# Altium schematic sheet Measurements.SchDoc
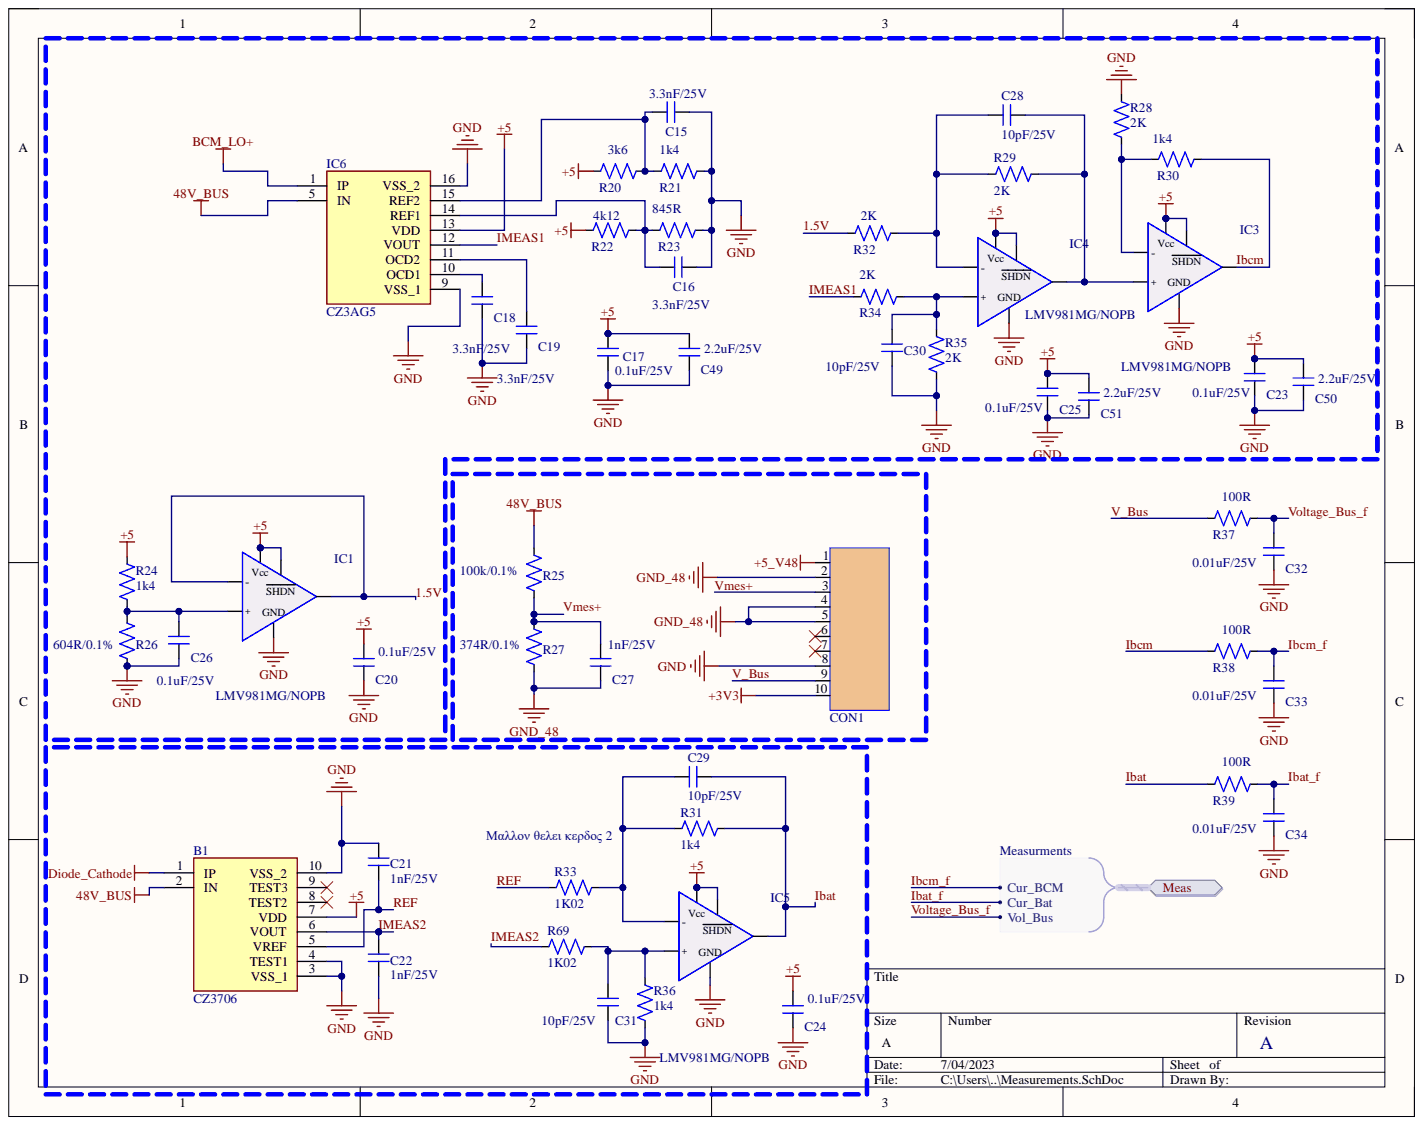
[diagram p0: Measurements.SchDoc]

## Parts
B1  CZ3706  [CZ3706]
C15  3.3nF/25V  [C0402]
C16  3.3nF/25V  [C0402]
C17  0.1uF/25V  [C0402]
C18  3.3nF/25V  [C0402]
C19  3.3nF/25V  [C0402]
C20  0.1uF/25V  [C0402]
C21  1nF/25V  [C0402]
C22  1nF/25V  [C0402]
C23  0.1uF/25V  [C0402]
C24  0.1uF/25V  [C0402]
C25  0.1uF/25V  [C0402]
C26  0.1uF/25V  [C0402]
C27  1nF/25V  [C0402]
C28  10pF/25V  [C0402]
C29  10pF/25V  [C0402]
C30  10pF/25V  [C0402]
C31  10pF/25V  [C0402]
C32  0.01uF/25V  [C0402]
C33  0.01uF/25V  [C0402]
C34  0.01uF/25V  [C0402]
C49  2.2uF/25V  [C0402]
C50  2.2uF/25V  [C0402]
C51  2.2uF/25V  [C0402]
CON1  SSQ-110-01-T-S  [Con1x10_2.54]  (value not drawn)
IC1  LMV981MG/NOPB  [SC-70-6]
IC3  LMV981MG/NOPB  [SC-70-6]
IC4  LMV981MG/NOPB  [SC-70-6]
IC5  LMV981MG/NOPB  [SC-70-6]
IC6  CZ3AG5  [CZ3AG5]
R20  3k6  [R0402]
R21  1k4  [R0402]
R22  4k12  [R0402]
R23  845R  [R0402]
R24  1k4  [R0402]
R25  100k/0.1%  [R0402]
R26  604R/0.1%  [R0402]
R27  374R/0.1%  [R0402]
R28  2K  [R0402]
R29  2K  [R0402]
R30  1k4  [R0402]
R31  1k4  [R0402]
R32  2K  [R0402]
R33  1K02  [R0402]
R34  2K  [R0402]
R35  2K  [R0402]
R36  1k4  [R0402]
R37  100R  [R0402]
R38  100R  [R0402]
R39  100R  [R0402]
R69  1K02  [R0402]

## Ports
Meas, Meas.Cur_Bat, Meas.Cur_BCM, Meas.Vol_Bus

## Nets
48V_BUS: B1.2(IN) IC6.5(IN) R25.2
+3V3: CON1.10
+5: B1.7(VDD) C17.1 C20.1 C23.1 C24.1 C25.1 C49.1 C50.1 C51.1 IC1.5 IC1.6 IC3.5 IC3.6 IC4.5 IC4.6 IC5.5 IC5.6 IC6.13(VDD) R20.1 R22.1 R24.2
+5_V48: CON1.1
BCM_LO+: IC6.1(IP)
Diode_Cathode: B1.1(IP)
GND: B1.3(VSS_1) B1.4(TEST1) B1.10(VSS_2) C15.2 C16.2 C17.2 C18.2 C19.2 C20.2 C21.2 C22.1 C23.2 C24.2 C25.2 C26.2 C30.2 C31.2 C32.2 C33.2 C34.2 C49.2 C50.2 C51.2 CON1.8 IC1.2 IC3.2 IC4.2 IC5.2 IC6.9(VSS_1) IC6.16(VSS_2) R21.2 R23.2 R26.1 R28.2 R35.1 R36.1
GND_48: C27.2 CON1.2 CON1.4 CON1.5 R27.1
1.5V: IC1.3(-) IC1.4 R32.1
Ibat: C29.2 IC5.4 R31.2 R39.1
Ibat_f: C34.1 R39.2 ; port Meas
Ibcm: IC3.4 R30.2 R38.1
Ibcm_f: C33.1 R38.2 ; port Meas
IMEAS1: IC6.12(VOUT) R34.1
IMEAS2: B1.6(VOUT) C22.2 R69.2
REF: B1.5(VREF) C21.1 R33.2
V_Bus: CON1.9 R37.1
Vmes+: C27.1 CON1.3 R25.1 R27.2
Voltage_Bus_f: C32.1 R37.2 ; port Meas
NetC15_1: C15.1 IC6.15(REF2) R20.2 R21.1
NetC16_1: C16.1 IC6.14(REF1) R22.2 R23.1
NetC18_1: C18.1 IC6.10(OCD1)
NetC19_1: C19.1 IC6.11(OCD2)
NetC26_1: C26.1 IC1.1(+) R24.1 R26.2
NetC28_1: C28.1 IC4.3(-) R29.1 R32.2
NetC28_2: C28.2 IC3.1(+) IC4.4 R29.2
NetC29_1: C29.1 IC5.3(-) R31.1 R33.1
NetC30_1: C30.1 IC4.1(+) R34.2 R35.2
NetC31_1: C31.1 IC5.1(+) R36.2 R69.1
NetIC3_3: IC3.3(-) R28.1 R30.1

Not connected: B1 8(TEST2) 9(TEST3); CON1 6 7

## Library data of the parts
B1 = CZ3706: Current Sensor 58.3A 1 Channel Hall Effect, Open Loop Bidirectional 16-PowerSOIC (0.303", 7.70mm Width), 10 Leads; Manufacturer_Name: AKM; Manufacturer_Part_Number: CZ3AG5; Geometry.Height: 2.45mm; Component Kind: Standard; Datasheet Link: https://www.akm.com/content/dam/documents/products/current-sensor/cz3ag5/cz3ag5-en-datasheet.pdf; Library Name: CZ3706.SchLib
C15 C16 C18 C19 = CC0402KRX7R8BB332: 0402 3.3 nF 25 V ±10 % Tolerance X7R SMT Mult; Manufacturer: Yageo; Capacitance: 3.3nF; Database Table Name: components; Dielectric Material: Ceramic; Tolerance: 10%; Voltage Rating: 25V; Case Code (Imperial): 0402; Case Code (Metric): 1005; Case/Package: 0402; Category: Ceramic Capacitors; Component Kind: Standard; Dielectric: X7R; Library Name: Resistors-Capacitors.DbLib; Manufacturer Part Number: CC0402KRX7R8BB332; Max Operating Temperature: 125°C; Min Operating Temperature: -55°C; Mount: Surface Mount; Packaging: Cut Tape; Part Number: CC0402KRX7R8BB332; Pricing 1: 1=0,10000, 10=0,01300, 50=0,01300, 100=0,0110; Resistor Type: Ceramic; RoHS: Yes; Stock 1: 41479; Supplier 1: Mouser; Supplier Part Number 1: 603-CC402KRX7R8BB332; Termination: SMD/SMT; Voltage Rating (DC): 25V; Weight: 2.3E-05oz
C17 C20 C23-C26 = C0402C104K3RACTU: Multilayer Ceramic 25V 0.1uF X7R 0402 10%; Manufacturer: Yageo; Database Table Name: components; Case/Package: 0402; Component Kind: Standard; Library Name: Resistors-Capacitors.DbLib; Part Number: C0402C104K3RACTU; Supplier 1: Mouser; Supplier Part Number 1: 80-C0402C104K3R
C21 C22 C27 = AC0402KRX7R8BB102: Cap Ceramic 0.001uF 25V X7R 10% SMD 0402 125°; Manufacturer: Yageo; Capacitance: 1nF; Database Table Name: components; Case Code (Imperial): 0402; Case Code (Metric): 1005; Case/Package: 0402; Category: Ceramic Capacitors; Component Kind: Standard; ComponentLink1Description: http://www.yageo.com/documents/recent/UPY-AC_; ComponentLink1URL: http://www.yageo.com/documents/recent/UPY-AC_; ComponentLink2Description: https://www.yageo.com/upload/media/product/pr; ComponentLink2URL: https://www.yageo.com/upload/media/product/pr; Library Name: Resistors-Capacitors.DbLib; Manufacturer Part Number: AC0402KRX7R8BB102; Max Operating Temperature: 125°C; Min Operating Temperature: -55°C; Mount: Surface Mount; Packaging: Cut Tape; Part Number: AC0402KRX7R8BB102; Pricing 1: 1=0,10000, 10=0,02700, 50=0,02700, 100=0,0220; Ratings: AEC-Q200; RoHS: Yes; RoHS Compliant: Yes; Stock 1: 53012; Supplier 1: Mouser; Supplier Part Number 1: 603-AC402KRX7R8BB102; Tolerance: 10%; Voltage Rating: 25V
C28-C31 = GRM1555C1E100GA01D: Cap Ceramic 10pF 25V C0G 2% Pad SMD 0402 125C; Manufacturer: Murata; Database Table Name: components; Capacitance: 10pF; Category: Ceramic Capacitors; Component Kind: Standard; Dielectric: C0G; Length: 1mm; Library Name: Resistors-Capacitors.DbLib; Manufacturer Part Number: GRM1555C1E100GA01D; Part Number: GRM1555C1E100GA01D; Pricing 1: 1=0,10000, 10=0,02600, 50=0,02600, 100=0,0120; RoHS: Yes; RoHS Compliant: Yes; Stock 1: 74874; Supplier 1: Mouser; Supplier Part Number 1: 81-GRM1555C1E100GA1D; Thickness: 500um; Tolerance: 2%; Voltage Rating (DC): 25V; Width: 500um
C32-C34 = C0402C103K3RAC7411: Multilayer Ceramic 25V 0.01uF X7R 0402 10%; Manufacturer: Yageo; Database Table Name: components; Case/Package: 0402; Component Kind: Standard; Library Name: Resistors-Capacitors.DbLib; Part Number: C0402C103K3RAC7411; Supplier 1: Mouser; Supplier Part Number 1: 80-C0402C103K3R7411
C49-C51 = GRM155C81E225ME11D: Ceramic 2.2UF 25V 20% 0402; Database Table Name: components; Case/Package: 0402; Component Kind: Standard; Library Name: Resistors-Capacitors.DbLib; Part Number: GRM155C81E225ME11D
CON1 = SSQ-110-01-T-S: Headers & Wire Housings Tiger Buy Socket Stri; Manufacturer: Samtec; Approvals: UR; Connector Type: Receptacle; Contact Current Rating: 3A; Contact Resistance: 10mR; Database Table Name: connectors; Gender: Female; Max Current Rating: 7.6A; Max Voltage Rating (AC): 550V; Number of Contacts: 10; Pin Length: 12mm; Pitch: 2.54mm; Plating Thickness: 0.381um; Row Spacing: 0.05inch; Case/Package: Module; Category: Headers and Wire Housings; Color: Black; Component Kind: Standard; ComponentLink1Description: https://datasheet.ciiva.com/748/ssq-1xx-xx-xx; ComponentLink1URL: https://datasheet.ciiva.com/748/ssq-1xx-xx-xx; ComponentLink2Description: https://datasheet.ciiva.com/409/m50-390-40926; ComponentLink2URL: https://datasheet.ciiva.com/409/m50-390-40926; ComponentLink3Description: https://datasheet.ciiva.com/8047/1693795-8047; ComponentLink3URL: https://datasheet.ciiva.com/8047/1693795-8047; ComponentLink4Description: https://datasheet.ciiva.com/8047/m50-390-8047; ComponentLink4URL: https://datasheet.ciiva.com/8047/m50-390-8047; ComponentLink5Description: https://datasheet.ciiva.com/699/eng-cs-130781; ComponentLink5URL: https://datasheet.ciiva.com/699/eng-cs-130781; ComponentLink6Description: https://datasheet.ciiva.com/1456/44697-145649; ComponentLink6URL: https://datasheet.ciiva.com/1456/44697-145649; ComponentLink7Description: https://datasheet.ciiva.com/11754/0900766b80d; ComponentLink7URL: https://datasheet.ciiva.com/11754/0900766b80d; ComponentLink8Description: https://datasheet.ciiva.com/1421/1759173-1421; ComponentLink8URL: https://datasheet.ciiva.com/1421/1759173-1421; ComponentLink9Description: https://datasheet.ciiva.com/16507/eng-cs-1307; ComponentLink9URL: https://datasheet.ciiva.com/16507/eng-cs-1307; ComponentLink10Description: https://datasheet.ciiva.com/30124/eng-cs-1307; ComponentLink10URL: https://datasheet.ciiva.com/30124/eng-cs-1307; ComponentLink11Description: https://datasheet.ciiva.com/14645/70086725-14; ComponentLink11URL: https://datasheet.ciiva.com/14645/70086725-14; ComponentLink12Description: https://datasheet.ciiva.com/767/eng-cd-881545; ComponentLink12URL: https://datasheet.ciiva.com/767/eng-cd-881545; ComponentLink13Description: https://datasheet.ciiva.com/775/9021150000-77; ComponentLink13URL: https://datasheet.ciiva.com/775/9021150000-77; ComponentLink14Description: https://datasheet.octopart.com/9021150000-Wei; ComponentLink14URL: https://datasheet.octopart.com/9021150000-Wei; ComponentLink15Description: https://datasheet.octopart.com/9021150000-Wei; ComponentLink15URL: https://datasheet.octopart.com/9021150000-Wei; Contact Gender: Female; Contact Material: Bronze; Contact Mating Length: 0.15inch; Contact Plating: Tin,Matte; Contact Type: Female Socket; Current Rating: 3A; Depth: 2.41mm; Flammability Rating: UL94 V-0; Height: 10.9mm; Housing Color: Black; Housing Material: Polymer; Lead Free: Lead Free; Length: 25.91mm; Library Name: Connectors.DbLib; Manufacturer Part Number: SSQ-110-01-T-S; Max Operating Temperature: 105°C; Min Operating Temperature: -55°C; Mount: Through Hole; Number of Positions: 10; Number of Rows: 1; Orientation: Straight; Package Quantity: 14000; Packaging: Bulk; Part Number: SSQ-110-01-T-S; Pricing 1: 1=1,75000, 10=1,59000, 50=1,59000, 100=1,3600; Radiation Hardening: No; Reach SVHC Compliant: Yes; RoHS: Yes; RoHS Compliant: Yes; Series: SSQ; Stock 1: 163; Supplier 1: Mouser; Supplier Part Number 1: 200-SSQ11001TS; Termination: Solder; Width: 2.41mm
IC1 IC3-IC5 = LMV981MG/NOPB: Op Amp Single Low Voltage Amplifier R-R I/O 5; Manufacturer: TI National Semiconductor; Bandwidth: 1.4MHz; Database Table Name: components; Number of Channels: 1; Output Current: 8mA; Case/Package: SC; Component Kind: Standard; Contact Plating: Tin; Library Name: ICs-smd.DbLib; Manufacturer Part Number: LMV981MG/NOPB; Max Operating Temperature: 125°C; Min Operating Temperature: -40°C; Mount: Surface Mount; Number of Pins: 6; Packaging: Cut Tape; Part Number: LMV981MG/NOPB; Pricing 1: 1=1,67000, 10=1,51000, 50=1,43000, 100=1,1800; RoHS: Yes; Stock 1: 8365; Supplier 1: Mouser; Supplier Part Number 1: 926-LMV981MG/NOPB; Weight: 0.01lb
IC6 = CZ3AG5: Current Sensor 58.3A 1 Channel Hall Effect, Open Loop Bidirectional 16-PowerSOIC (0.303", 7.70mm Width), 10 Leads; Manufacturer_Name: AKM; Geometry.Height: 2.45mm; Component Kind: Standard; Datasheet Link: https://www.akm.com/content/dam/documents/products/current-sensor/cz3ag5/cz3ag5-en-datasheet.pdf; Library Name: CZ3AG5.SchLib; Manufacturer_Part_Number: CZ3AG5
R20 = RC0402FR-073K6L: Res Thick Film 0402 3.6K Ohm 1% 0.063W(1/16W); Manufacturer: Yageo; Database Table Name: components; Max Power Dissipation: 63mW; Power Rating: 62.5mW; Resistance: 3.6kR; Temperature Coefficient: 100ppm/°C; Voltage Rating: 50V; Case Code (Imperial): 0402; Case Code (Metric): 1005; Case/Package: 0402; Category: Chip SMD Resistors; Component Kind: Standard; ComponentLink1Description: https://datasheet.ciiva.com/2553/pyu-r-int-th; ComponentLink1URL: https://datasheet.ciiva.com/2553/pyu-r-int-th; ComponentLink2Description: https://datasheet.ciiva.com/2553/pyu-r-int-th; ComponentLink2URL: https://datasheet.ciiva.com/2553/pyu-r-int-th; ComponentLink3Description: https://datasheet.ciiva.com/2553/pyu-r-int-th; ComponentLink3URL: https://datasheet.ciiva.com/2553/pyu-r-int-th; ComponentLink4Description: https://datasheet.ciiva.com/15189/getdatashee; ComponentLink4URL: https://datasheet.ciiva.com/15189/getdatashee; Construction: Rectangular; Depth: 0.5mm; Features: Moisture Resistant; Height: 0.016inch; Lead Free: Lead Free; Length: 1mm; Library Name: Resistors-Capacitors.DbLib; Manufacturer Part Number: RC0402FR-073K6L; Max Operating Temperature: 155°C; Military Standard: Not; Min Operating Temperature: -55°C; Number of Pins: 2; Number of Terminations: 2; Package Quantity: 1; Packaging: Tape and Reel; Part Number: RC0402FR-073K6L; Pricing 1: 1=0,10000, 10=0,01200, 50=0,01200, 100=0,0050; Reach SVHC Compliant: Yes; Resistance Tolerance: 1%; Resistor Type: Thick Film; RoHS: Yes; RoHS Compliant: Yes; Series: RC; Size Code: 0402; Stock 1: 304505; Supplier 1: Mouser; Supplier Part Number 1: 603-RC0402FR-073K6L; Termination: SMD/SMT; Tolerance: 1%; Voltage Rating (DC): 50V; Weight: 2.3E-05oz; Width: 0.5mm
R21 R24 R30 R31 R36 = RC0402FR-071K4L: Res General Purpose Thick Film 0402 1.4K Ohm; Manufacturer: Yageo; Database Table Name: components; Max Power Dissipation: 63mW; Power Rating: 62.5mW; Resistance: 1.4kR; Temperature Coefficient: 100ppm/°C; Tolerance: 1%; Voltage Rating: 50V; Case Code (Imperial): 0402; Case Code (Metric): 1005; Case/Package: 0402; Category: Chip SMD Resistors; Component Kind: Standard; ComponentLink1Description: https://datasheet.ciiva.com/8895/pyu-rc0402-5; ComponentLink1URL: https://datasheet.ciiva.com/8895/pyu-rc0402-5; Construction: Rectangular; Contact Plating: Tin,Matte; Depth: 0.5mm; Features: Moisture Resistant; Height: 0.016inch; Lead Free: Lead Free; Length: 1mm; Library Name: Resistors-Capacitors.DbLib; Manufacturer Part Number: RC0402FR-071K4L; Max Operating Temperature: 155°C; Military Standard: Not; Min Operating Temperature: -55°C; Number of Terminations: 2; Packaging: Tape and Reel; Part Number: RC0402FR-071K4L; Pricing 1: 1=0,10000, 10=0,01200, 50=0,01200, 100=0,0050; Radiation Hardening: No; Resistor Type: Thick Film; RoHS: Yes; RoHS Compliant: Yes; Series: RC; Size Code: 0402; Stock 1: 159478; Supplier 1: Mouser; Supplier Part Number 1: 603-RC0402FR-071K4L; Termination: SMD/SMT; Voltage Rating (DC): 50V; Width: 0.5mm
R22 = RC0402FR-074K22L: RES SMD 4.22K OHM 1% 1/16W 0402; Manufacturer: Yageo; Database Table Name: components; Max Power Dissipation: 63mW; Power Rating: 62.5mW; Resistance: 4.22kR; Temperature Coefficient: 100ppm/°C; Voltage Rating: 50V; Case Code (Imperial): 0402; Case Code (Metric): 1005; Case/Package: 0402; Category: Chip SMD Resistors; Component Kind: Standard; ComponentLink1Description: https://datasheet.ciiva.com/5558/pyu-rc0402-5; ComponentLink1URL: https://datasheet.ciiva.com/5558/pyu-rc0402-5; ComponentLink2Description: https://datasheet.ciiva.com/8893/pyu-rc0402-5; ComponentLink2URL: https://datasheet.ciiva.com/8893/pyu-rc0402-5; ComponentLink3Description: https://datasheet.ciiva.com/26830/getdatashee; ComponentLink3URL: https://datasheet.ciiva.com/26830/getdatashee; Construction: Rectangular; Contact Plating: Tin,Matte; Depth: 0.5mm; Features: Moisture Resistant; Height: 0.016inch; Lead Free: Lead Free; Length: 1mm; Library Name: Resistors-Capacitors.DbLib; Manufacturer Part Number: RC0402FR-074K22L; Max Operating Temperature: 155°C; Military Standard: Not; Min Operating Temperature: -55°C; Number of Terminations: 2; Packaging: Tape and Reel; Part Number: RC0402FR-074K22L; Pricing 1: 1=0,10000, 10=0,01400, 50=0,01400, 100=0,0050; Resistor Type: Thick Film; RoHS: Yes; RoHS Compliant: Yes; Series: RC; Size Code: 0402; Stock 1: 51550; Supplier 1: Mouser; Supplier Part Number 1: 603-RC0402FR-074K22L; Termination: SMD/SMT; Tolerance: 1%; Voltage Rating (DC): 50V; Width: 0.02inch
R23 = RC0402FR-07845RL: Res Thick Film 0402 845 Ohm 1% 1/16W ±100ppm/; Manufacturer: Yageo; Database Table Name: components; Max Power Dissipation: 63mW; Power Rating: 62.5mW; Temperature Coefficient: 100ppm/°C; Voltage Rating: 50V; Case Code (Imperial): 0402; Case Code (Metric): 1005; Case/Package: 0402; Category: Chip SMD Resistors; Component Kind: Standard; ComponentLink1Description: https://datasheet.ciiva.com/17423/pyu-rc0402-; ComponentLink1URL: https://datasheet.ciiva.com/17423/pyu-rc0402-; Construction: Rectangular; Contact Plating: Tin,Matte; Depth: 0.5mm; Features: Moisture Resistant; Height: 0.016inch; Lead Free: Lead Free; Length: 1mm; Library Name: Resistors-Capacitors.DbLib; Manufacturer Part Number: RC0402FR-07845RL; Max Operating Temperature: 155°C; Military Standard: Not; Min Operating Temperature: -55°C; Number of Terminations: 2; Packaging: Tape and Reel; Part Number: RC0402FR-07845RL; Pricing 1: 1=0,10000, 10=0,01200, 50=0,01200, 100=0,0050; Resistance: 845R; Resistor Type: Thick Film; RoHS: Yes; RoHS Compliant: Yes; Series: RC; Size Code: 0402; Stock 1: 37160; Supplier 1: Mouser; Supplier Part Number 1: 603-RC0402FR-07845RL; Termination: SMD/SMT; Tolerance: 1%; Width: 0.5mm
R25 = RT0603BRD07100KL: RES SMD 100K OHM 0.1% 1/10W 0603; Manufacturer: Yageo; Database Table Name: components; Max Power Dissipation: 100mW; Resistance: 100kR; Temperature Coefficient: 25ppm/°C; Voltage Rating: 75V; Case Code (Imperial): 0603; Case Code (Metric): 1608; Case/Package: 0603; Category: Chip SMD Resistors; Component Kind: Standard; ComponentLink1Description: https://datasheet.ciiva.com/11819/0900766b807; ComponentLink1URL: https://datasheet.ciiva.com/11819/0900766b807; Construction: Rectangular; Depth: 0.8mm; Features: Moisture Resistant; Height: 0.022inch; Lead Free: Lead Free; Length: 1.6mm; Library Name: Resistors-Capacitors.DbLib; Manufacturer Part Number: RT0603BRD07100KL; Max Operating Temperature: 125°C; Military Standard: Not; Min Operating Temperature: -55°C; Mount: Surface Mount; Number of Terminations: 2; Package Quantity: 5000; Packaging: Tape and Reel; Part Number: RT0603BRD07100KL; Power Rating: 100mW; Pricing 1: 1=0,33000, 10=0,25600, 50=0,25600, 100=0,1080; Resistor Type: Thin Film; RoHS: Yes; RoHS Compliant: Yes; Series: RT; Stock 1: 117151; Supplier 1: Mouser; Supplier Part Number 1: 603-RT0603BRD07100KL; Technology: THIN FILM; Termination: SMD/SMT; Tolerance: 0.1%; Voltage Rating (DC): 75V; Width: 0.031inch; Working Voltage: 75V
R26 = RT0402BRD07604RL: Res Thin Film 0402 604 Ohm 0.1% 1/16W ±25ppm/; Manufacturer: Yageo; Database Table Name: components; Max Power Dissipation: 63mW; Power Rating: 62.5mW; Resistance: 604R; Temperature Coefficient: 25ppm/°C; Voltage Rating: 50V; Case Code (Imperial): 0402; Case Code (Metric): 1005; Case/Package: 0402; Category: Chip SMD Resistors; Component Kind: Standard; ComponentLink1Description: https://datasheet.ciiva.com/2582/pyu-rt-1-to-; ComponentLink1URL: https://datasheet.ciiva.com/2582/pyu-rt-1-to-; ComponentLink2Description: https://datasheet.ciiva.com/12723/pyu-rt-1-to; ComponentLink2URL: https://datasheet.ciiva.com/12723/pyu-rt-1-to; Height: 0.014inch; Lead Free: Lead Free; Length: 0.039inch; Library Name: Resistors-Capacitors.DbLib; Manufacturer Part Number: RT0402BRD07604RL; Max Operating Temperature: 125°C; Min Operating Temperature: -55°C; Number of Terminations: 2; Package Quantity: 10000; Packaging: Tape and Reel; Part Number: RT0402BRD07604RL; Pricing 1: 1=0,36000, 10=0,26100, 50=0,26100, 100=0,1050; Resistor Type: Thin Film; RoHS: Yes; RoHS Compliant: Yes; Series: RT; Stock 1: 5469; Supplier 1: Mouser; Supplier Part Number 1: 603-RT0402BRD07604RL; Termination: SMD/SMT; Tolerance: 0.1%; Voltage Rating (DC): 50V; Width: 0.02inch
R27 = RT0603BRD07374RL: Res Thin Film 0603 374 Ohm 0.1% 1/10W ±25ppm/; Manufacturer: Yageo; Database Table Name: components; Max Power Dissipation: 100mW; Resistance: 374R; Temperature Coefficient: 25ppm/°C; Voltage Rating: 75V; Voltage Rating (DC): 50V; Case Code (Imperial): 0603; Case Code (Metric): 1608; Case/Package: 0603; Category: Chip SMD Resistors; Component Kind: Standard; ComponentLink1Description: https://datasheet.ciiva.com/2585/pyu-rt-1-to-; ComponentLink1URL: https://datasheet.ciiva.com/2585/pyu-rt-1-to-; ComponentLink2Description: https://datasheet.ciiva.com/12702/pyu-rt-1-to; ComponentLink2URL: https://datasheet.ciiva.com/12702/pyu-rt-1-to; ComponentLink3Description: https://datasheet.ciiva.com/46635/pyu-rt-1-to; ComponentLink3URL: https://datasheet.ciiva.com/46635/pyu-rt-1-to; Features: Moisture Resistant; Height: 0.022inch; Lead Free: Lead Free; Length: 0.063inch; Library Name: Resistors-Capacitors.DbLib; Manufacturer Part Number: RT0603BRD07374RL; Max Operating Temperature: 155°C; Min Operating Temperature: -55°C; Number of Terminations: 2; Package Quantity: 5000; Packaging: Tape and Reel; Part Number: RT0603BRD07374RL; Power Rating: 100mW; Pricing 1: 1=0,33000, 10=0,27400, 50=0,27400, 100=0,1080; Resistor Type: Thin Film; RoHS: Yes; RoHS Compliant: Yes; Series: RT; Stock 1: 5833; Supplier 1: Mouser; Supplier Part Number 1: 603-RT0603BRD07374RL; Termination: SMD/SMT; Tolerance: 0.1%; Width: 0.031inch
R28 R29 R32 R34 R35 = RC0402FR-072KL: Res General Purpose Thick Film 0402 2K Ohm 1%; Manufacturer: Yageo; Database Table Name: components; Max Power Dissipation: 63mW; Resistance: 2kR; Temperature Coefficient: 100ppm/°C; Voltage Rating: 50V; Case Code (Imperial): 0402; Case Code (Metric): 1005; Case/Package: 0402; Category: Chip SMD Resistors; Component Kind: Standard; ComponentLink1Description: https://datasheet.ciiva.com/11808/0900766b80c; ComponentLink1URL: https://datasheet.ciiva.com/11808/0900766b80c; ComponentLink2Description: https://datasheet.ciiva.com/2453/pyu-r-int-th; ComponentLink2URL: https://datasheet.ciiva.com/2453/pyu-r-int-th; ComponentLink3Description: https://datasheet.ciiva.com/2453/pyu-r-int-th; ComponentLink3URL: https://datasheet.ciiva.com/2453/pyu-r-int-th; ComponentLink4Description: https://datasheet.ciiva.com/2453/pyu-r-int-th; ComponentLink4URL: https://datasheet.ciiva.com/2453/pyu-r-int-th; ComponentLink5Description: https://datasheet.ciiva.com/15189/getdatashee; ComponentLink5URL: https://datasheet.ciiva.com/15189/getdatashee; ComponentLink6Description: https://datasheet.ciiva.com/12667/pyu-rc0402-; ComponentLink6URL: https://datasheet.ciiva.com/12667/pyu-rc0402-; ComponentLink7Description: https://datasheet.ciiva.com/7983/1018594-7983; ComponentLink7URL: https://datasheet.ciiva.com/7983/1018594-7983; ComponentLink8Description: https://datasheet.ciiva.com/7849/1018594-7849; ComponentLink8URL: https://datasheet.ciiva.com/7849/1018594-7849; ComponentLink9Description: https://datasheet.ciiva.com/7849/1018594-7849; ComponentLink9URL: https://datasheet.ciiva.com/7849/1018594-7849; Construction: Rectangular; Depth: 0.5mm; Features: Moisture Resistant; Height: 0.016inch; Lead Free: Lead Free; Length: 1mm; Library Name: Resistors-Capacitors.DbLib; Manufacturer Part Number: RC0402FR-072KL; Max Operating Temperature: 155°C; Military Standard: Not; Min Operating Temperature: -55°C; Number of Terminations: 2; Package Quantity: 1; Packaging: Tape and Reel; Part Number: RC0402FR-072KL; Power Rating: 63mW; Pricing 1: 1=0.10000, 10=0.01600, 50=0.01600, 100=0.0070; REACH SVHC: Unknown; Resistance Tolerance: 1%; Resistor Type: Thick Film; RoHS: Yes; RoHS Compliant: Yes; Series: RC; Stock 1: 259736; Supplier 1: Mouser; Supplier Part Number 1: 603-RC0402FR-072KL; Technology: THICK FILM; Termination: SMD/SMT; Tolerance: 1%; Voltage Rating (DC): 50V; Width: 0.02inch; Working Voltage: 50V
R33 R69 = RC0402FR-071K02L: Res Thick Film 0402 1.02K Ohm 1% 1/16W ±100pp; Manufacturer: Yageo; Database Table Name: components; Case/Package: 0402; Category: Chip SMD Resistors; Component Kind: Standard; Library Name: Resistors-Capacitors.DbLib; Manufacturer Part Number: RC0402FR-071K02L; Part Number: RC0402FR-071K02L; Pricing 1: 1=0.10000, 10=0.01400, 50=0.01400, 100=0.0060; RoHS: Yes; Stock 1: 55716; Supplier 1: Mouser; Supplier Part Number 1: 603-RC0402FR-071K02L
R37-R39 = RT0402FRE07100RL: Res Thin Film 0402 100 Ohm 1% 0.063W(1/16W) ±; Manufacturer: Yageo; Database Table Name: components; Max Power Dissipation: 63mW; Power Rating: 62.5mW; Temperature Coefficient: 50ppm/°C; Voltage Rating: 50V; Case Code (Imperial): 0402; Case Code (Metric): 1005; Case/Package: 0402; Category: Chip SMD Resistors; Component Kind: Standard; ComponentLink1Description: https://datasheet.ciiva.com/12836/pyu-rt-1-to; ComponentLink1URL: https://datasheet.ciiva.com/12836/pyu-rt-1-to; ComponentLink2Description: https://datasheet.ciiva.com/8887/pyu-rt-1-to-; ComponentLink2URL: https://datasheet.ciiva.com/8887/pyu-rt-1-to-; Construction: Rectangular; Contact Plating: Tin,Matte; Depth: 0.5mm; Features: Moisture Resistant; Height: 0.014inch; Lead Free: Lead Free; Length: 1mm; Library Name: Resistors-Capacitors.DbLib; Manufacturer Part Number: RT0402FRE07100RL; Max Operating Temperature: 125°C; Military Standard: Not; Min Operating Temperature: -55°C; Number of Terminations: 2; Package Quantity: 10000; Packaging: Tape and Reel; Part Number: RT0402FRE07100RL; Pricing 1: 1=0,10000, 10=0,07000, 50=0,07000, 100=0,0290; Radiation Hardening: No; Resistance: 100R; Resistor Type: Thin Film; RoHS: Yes; RoHS Compliant: Yes; Series: RT; Size Code: 0402; Stock 1: 800109; Supplier 1: Mouser; Supplier Part Number 1: 603-RT0402FRE07100RL; Termination: SMD/SMT; Tolerance: 1%; Voltage Rating (DC): 50V; Weight: 2.3E-05oz; Width: 0.02inch
